# Altium schematic sheet BREEZE Pro ESC-B V1.SchDoc
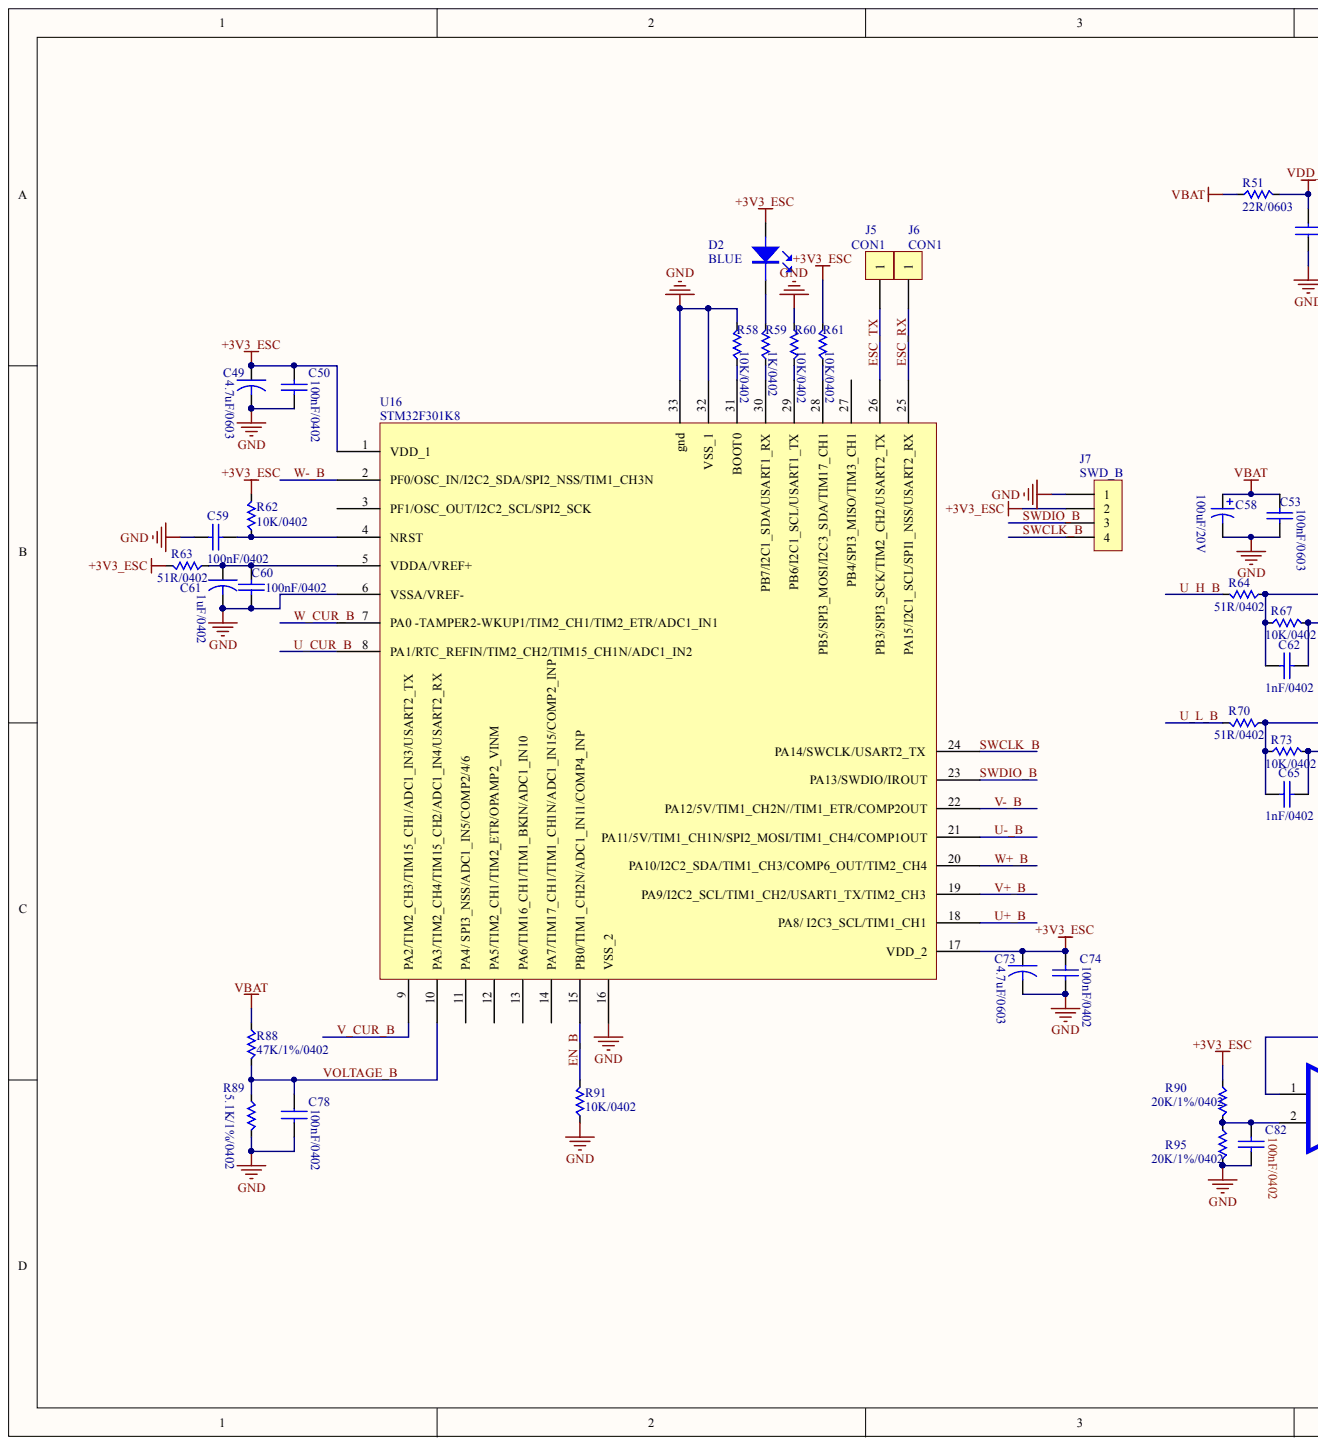
[diagram p0: BREEZE Pro ESC-B V1.SchDoc, left]
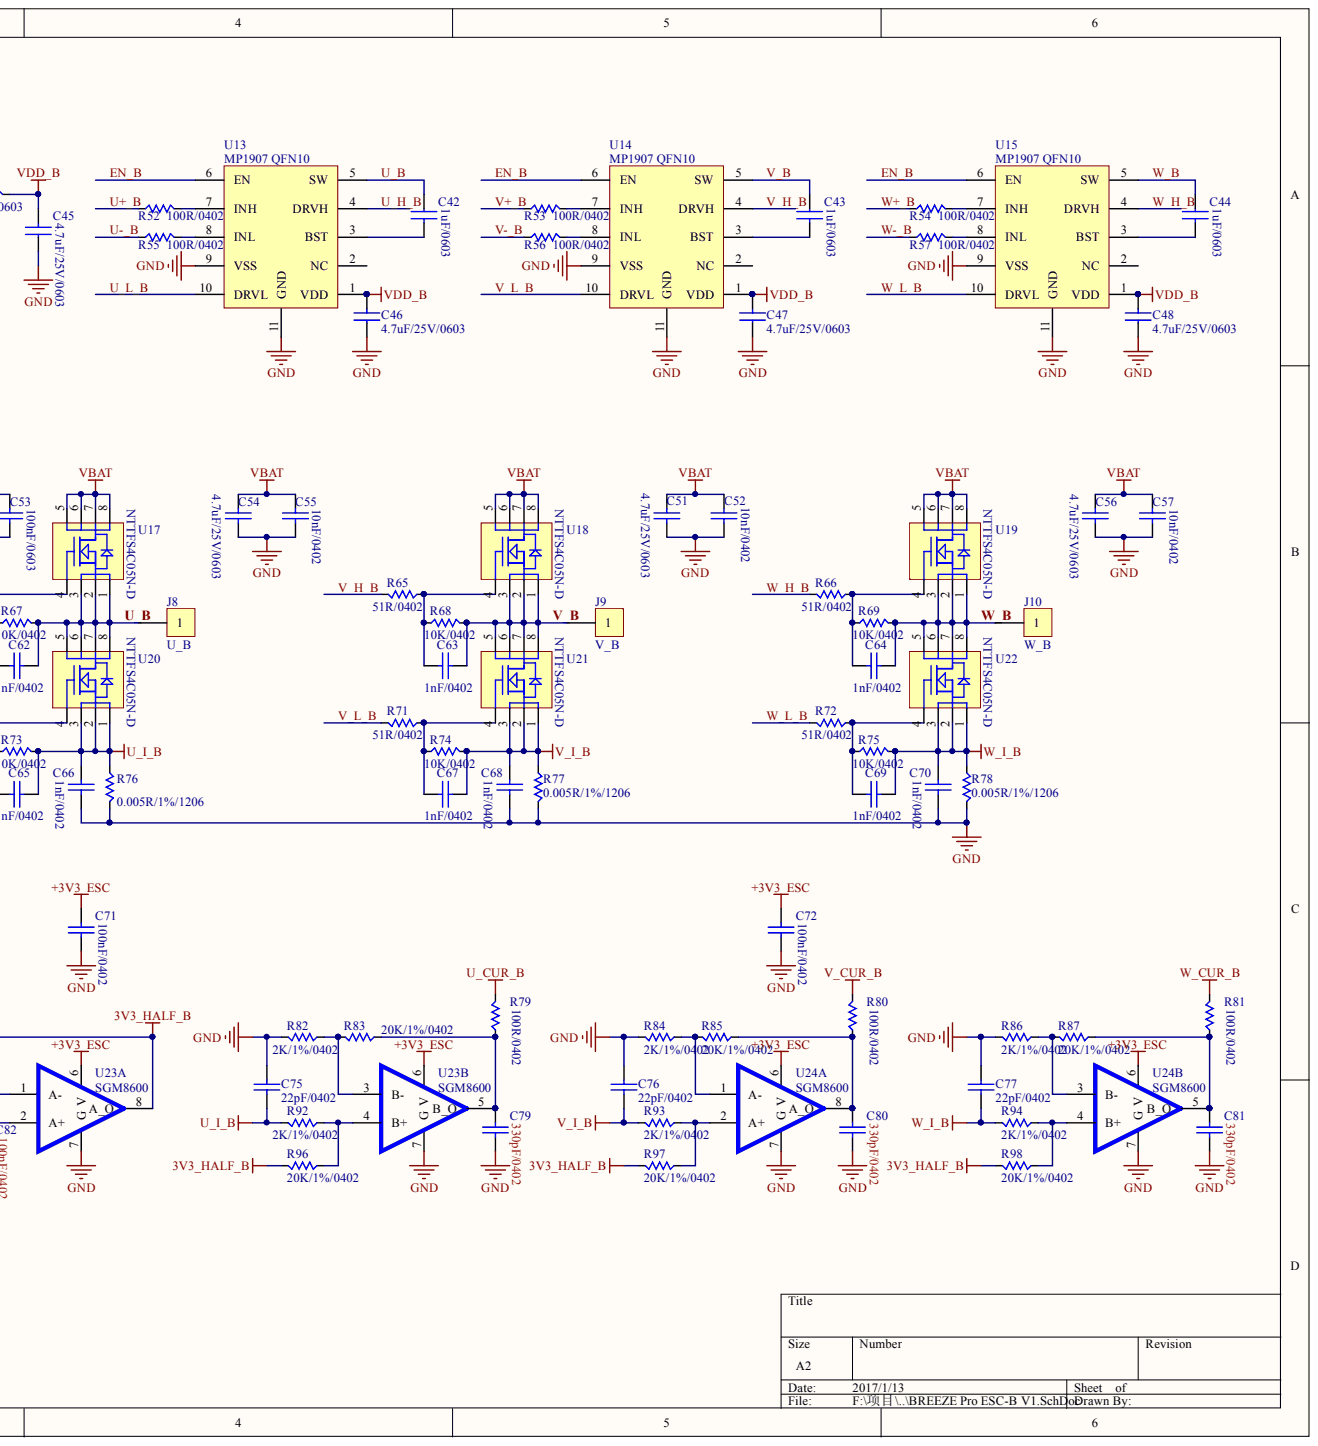
[diagram p1: BREEZE Pro ESC-B V1.SchDoc, right]

## Parts
C42  1uF/0603
C43  1uF/0603
C44  1uF/0603
C45  4.7uF/25V/0603
C46  4.7uF/25V/0603
C47  4.7uF/25V/0603
C48  4.7uF/25V/0603
C49  4.7uF/0603
C50  100nF/0402
C51  4.7uF/25V/0603
C52  10nF/0402
C53  100nF/0603
C54  4.7uF/25V/0603
C55  10nF/0402
C56  4.7uF/25V/0603
C57  10nF/0402
C58  100uF/20V
C59  100nF/0402
C60  100nF/0402
C61  1uF/0402
C62  1nF/0402
C63  1nF/0402
C64  1nF/0402
C65  1nF/0402
C66  1nF/0402
C67  1nF/0402
C68  1nF/0402
C69  1nF/0402
C70  1nF/0402
C71  100nF/0402
C72  100nF/0402
C73  4.7uF/0603
C74  100nF/0402
C75  22pF/0402
C76  22pF/0402
C77  22pF/0402
C78  100nF/0402
C79  330pF/0402
C80  330pF/0402
C81  330pF/0402
C82  100nF/0402
D2  BLUE
J5  CON1
J6  CON1
J7  SWD_B
J8  U_B
J9  V_B
J10  W_B
R51  22R/0603
R52  100R/0402
R53  100R/0402
R54  100R/0402
R55  100R/0402
R56  100R/0402
R57  100R/0402
R58  10K/0402
R59  1K/0402
R60  10K/0402
R61  10K/0402
R62  10K/0402
R63  51R/0402
R64  51R/0402
R65  51R/0402
R66  51R/0402
R67  10K/0402
R68  10K/0402
R69  10K/0402
R70  51R/0402
R71  51R/0402
R72  51R/0402
R73  10K/0402
R74  10K/0402
R75  10K/0402
R76  0.005R/1%/1206
R77  0.005R/1%/1206
R78  0.005R/1%/1206
R79  100R/0402
R80  100R/0402
R81  100R/0402
R82  2K/1%/0402
R83  20K/1%/0402
R84  2K/1%/0402
R85  20K/1%/0402
R86  2K/1%/0402
R87  20K/1%/0402
R88  47K/1%/0402
R89  5.1K/1%/0402
R90  20K/1%/0402
R91  10K/0402
R92  2K/1%/0402
R93  2K/1%/0402
R94  2K/1%/0402
R95  20K/1%/0402
R96  20K/1%/0402
R97  20K/1%/0402
R98  20K/1%/0402
U13  MP1907 QFN10
U14  MP1907 QFN10
U15  MP1907 QFN10
U16  STM32F301K8
U17  NTTFS4C05N-D
U18  NTTFS4C05N-D
U19  NTTFS4C05N-D
U20  NTTFS4C05N-D
U21  NTTFS4C05N-D
U22  NTTFS4C05N-D
U23  SGM8600  (parts A,B)
U24  SGM8600  (parts A,B)

## Nets
3V3_HALF_B: R96.2 R97.2 R98.2 U23.1(A-) U23.8(A_O)
+3V3_ESC: C49.1 C50.1 C71.1 C72.1 C73.1 C74.1 D2.1 J7.2 R61.2 R62.2 R63.2 R90.1 U16.1(VDD_1) U16.17(VDD_2) U23.6(V) U24.6(V)
GND: C45.1 C46.1 C47.1 C48.1 C49.2 C50.2 C51.2 C52.2 C53.2 C54.2 C55.2 C56.2 C57.2 C58.2 C59.2 C60.2 C61.2 C66.2 C68.2 C70.2 C71.2 C72.2 C73.2 C74.2 C75.1 C76.1 C77.1 C78.2 C79.1 C80.1 C81.1 C82.1 J7.1 R58.2 R60.1 R76.2 R77.2 R78.2 R82.2 R84.2 R86.2 R89.1 R91.1 R95.2 U13.9(VSS) U13.11(GND) U14.9(VSS) U14.11(GND) U15.9(VSS) U15.11(GND) U16.6(VSSA/VREF-) U16.16(VSS_2) U16.32(VSS_1) U16.33(gnd) U23.7(G) U24.7(G)
U_I_B: C65.2 C66.1 C75.2 R73.2 R76.1 R92.2 U20.1 U20.2 U20.3
V_I_B: C67.2 C68.1 C76.2 R74.2 R77.1 R93.2 U21.1 U21.2 U21.3
VBAT: C51.1 C52.1 C53.1 C54.1 C55.1 C56.1 C57.1 C58.1 R51.1 R88.2 U17.5 U17.6 U17.7 U17.8 U18.5 U18.6 U18.7 U18.8 U19.5 U19.6 U19.7 U19.8
VDD_B: C45.2 C46.2 C47.2 C48.2 R51.2 U13.1(VDD) U14.1(VDD) U15.1(VDD)
W_I_B: C69.2 C70.1 C77.2 R75.2 R78.1 R94.2 U22.1 U22.2 U22.3
EN_B: R91.2 U13.6(EN) U14.6(EN) U15.6(EN) U16.15(PB0/TIM1_CH2N/ADC1_IN11/COMP4_INP)
ESC_RX: J6.1 U16.25(PA15/I2C1_SCL/SPI1_NSS/USART2_RX)
ESC_TX: J5.1 U16.26(PB3/SPI3_SCK/TIM2_CH2/USART2_TX)
SWCLK_B: J7.4 U16.24(PA14/SWCLK/USART2_TX)
SWDIO_B: J7.3 U16.23(PA13/SWDIO/IROUT)
U+_B: R52.1 U16.18(PA8/_I2C3_SCL/TIM1_CH1)
U-_B: R55.1 U16.21(PA11/5V/TIM1_CH1N/SPI2_MOSI/TIM1_CH4/COMP1OUT)
U_B: C42.1 C62.2 J8.1 R67.2 U13.5(SW) U17.1 U17.2 U17.3 U20.5 U20.6 U20.7 U20.8
U_CUR_B: R79.2 U16.8(PA1/RTC_REFIN/TIM2_CH2/TIM15_CH1N/ADC1_IN2)
U_H_B: R64.2 U13.4(DRVH)
U_L_B: R70.2 U13.10(DRVL)
V+_B: R53.1 U16.19(PA9/I2C2_SCL/TIM1_CH2/USART1_TX/TIM2_CH3)
V-_B: R56.1 U16.22(PA12/5V/TIM1_CH2N//TIM1_ETR/COMP2OUT)
V_B: C43.1 C63.2 J9.1 R68.2 U14.5(SW) U18.1 U18.2 U18.3 U21.5 U21.6 U21.7 U21.8
V_CUR_B: R80.2 U16.9(PA2/TIM2_CH3/TIM15_CH1/ADC1_IN3/USART2_TX)
V_H_B: R65.2 U14.4(DRVH)
V_L_B: R71.2 U14.10(DRVL)
VOLTAGE_B: C78.1 R88.1 R89.2 U16.10(PA3/TIM2_CH4/TIM15_CH2/ADC1_IN4/USART2_RX)
W+_B: R54.1 U16.20(PA10/I2C2_SDA/TIM1_CH3/COMP6_OUT/TIM2_CH4)
W-_B: R57.1 U16.2(PF0/OSC_IN/I2C2_SDA/SPI2_NSS/TIM1_CH3N)
W_B: C44.1 C64.2 J10.1 R69.2 U15.5(SW) U19.1 U19.2 U19.3 U22.5 U22.6 U22.7 U22.8
W_CUR_B: R81.2 U16.7(PA0_-TAMPER2-WKUP1/TIM2_CH1/TIM2_ETR/ADC1_IN1)
W_H_B: R66.2 U15.4(DRVH)
W_L_B: R72.2 U15.10(DRVL)
NetC42_2: C42.2 U13.3(BST)
NetC43_2: C43.2 U14.3(BST)
NetC44_2: C44.2 U15.3(BST)
NetC59_1: C59.1 R62.1 U16.4(NRST)
NetC60_1: C60.1 C61.1 R63.1 U16.5(VDDA/VREF+)
NetC62_1: C62.1 R64.1 R67.1 U17.4
NetC63_1: C63.1 R65.1 R68.1 U18.4
NetC64_1: C64.1 R66.1 R69.1 U19.4
NetC65_1: C65.1 R70.1 R73.1 U20.4
NetC67_1: C67.1 R71.1 R74.1 U21.4
NetC69_1: C69.1 R72.1 R75.1 U22.4
NetC79_2: C79.2 R79.1 R83.1 U23.5(B_O)
NetC80_2: C80.2 R80.1 R85.1 U24.8(A_O)
NetC81_2: C81.2 R81.1 R87.1 U24.5(B_O)
NetC82_2: C82.2 R90.2 R95.1 U23.2(A+)
NetD2_2: D2.2 R59.1
NetR52_2: R52.2 U13.7(INH)
NetR53_2: R53.2 U14.7(INH)
NetR54_2: R54.2 U15.7(INH)
NetR55_2: R55.2 U13.8(INL)
NetR56_2: R56.2 U14.8(INL)
NetR57_2: R57.2 U15.8(INL)
NetR58_1: R58.1 U16.31(BOOT0)
NetR59_2: R59.2 U16.30(PB7/I2C1_SDA/USART1_RX)
NetR60_2: R60.2 U16.29(PB6/I2C1_SCL/USART1_TX)
NetR61_1: R61.1 U16.28(PB5/SPI3_MOSI/I2C3_SDA/TIM17_CH1)
NetR82_1: R82.1 R83.2 U23.3(22pF/0402)
NetR84_1: R84.1 R85.2 U24.1(A-)
NetR86_1: R86.1 R87.2 U24.3(22pF/0402)
NetR92_1: R92.1 R96.1 U23.4
NetR93_1: R93.1 R97.1 U24.2(A+)
NetR94_1: R94.1 R98.1 U24.4

Not connected: U13 2(NC); U14 2(NC); U15 2(NC); U16 3(PF1/OSC_OUT/I2C2_SCL/SPI2_SCK) 11(PA4/_SPI3_NSS/ADC1_IN5/COMP2/4/6) 12(PA5/TIM2_CH1/TIM2_ETR/OPAMP2_VINM) 13(PA6/TIM16_CH1/TIM1_BKIN/ADC1_IN10) 14(PA7/TIM17_CH1/TIM1_CH1N/ADC1_IN15/COMP2_INP) 27(PB4/SPI3_MISO/TIM3_CH1)

## Library data of the parts
C42-C48 C78 = (no symbol name): Text Field1: 20; Text Field2: 10
C49-C77 C79-C82 D2 J5-J10 R51-R98 U13-U24 = (no symbol name)
  C58: Published: 8-Jun-2000; LatestRevisionDate: 17-Jul-2002; LatestRevisionNote: Re-released for DXP Platform.; PackageReference: RB7.6-15; Publisher: Altium Limited
